# Altium schematic sheet Sensors_and_Comms.SchDoc(Sensors_and_Comms)
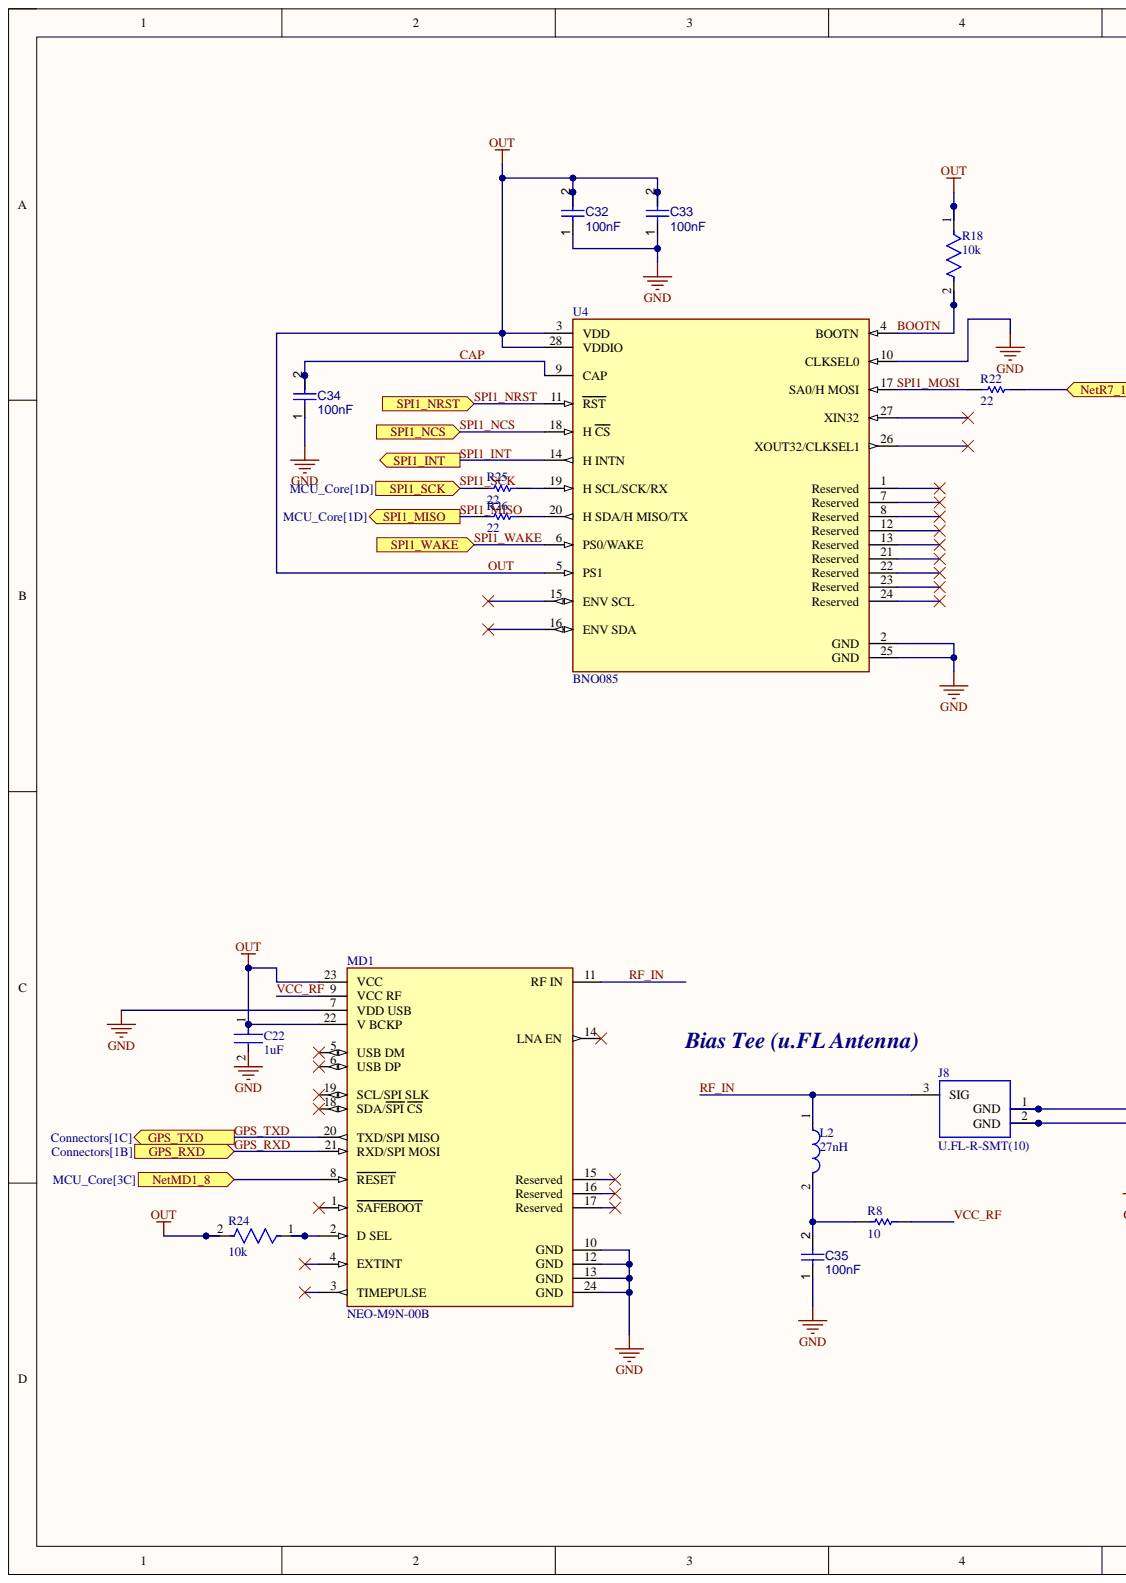
[diagram p0: Sensors_and_Comms.SchDoc, left]
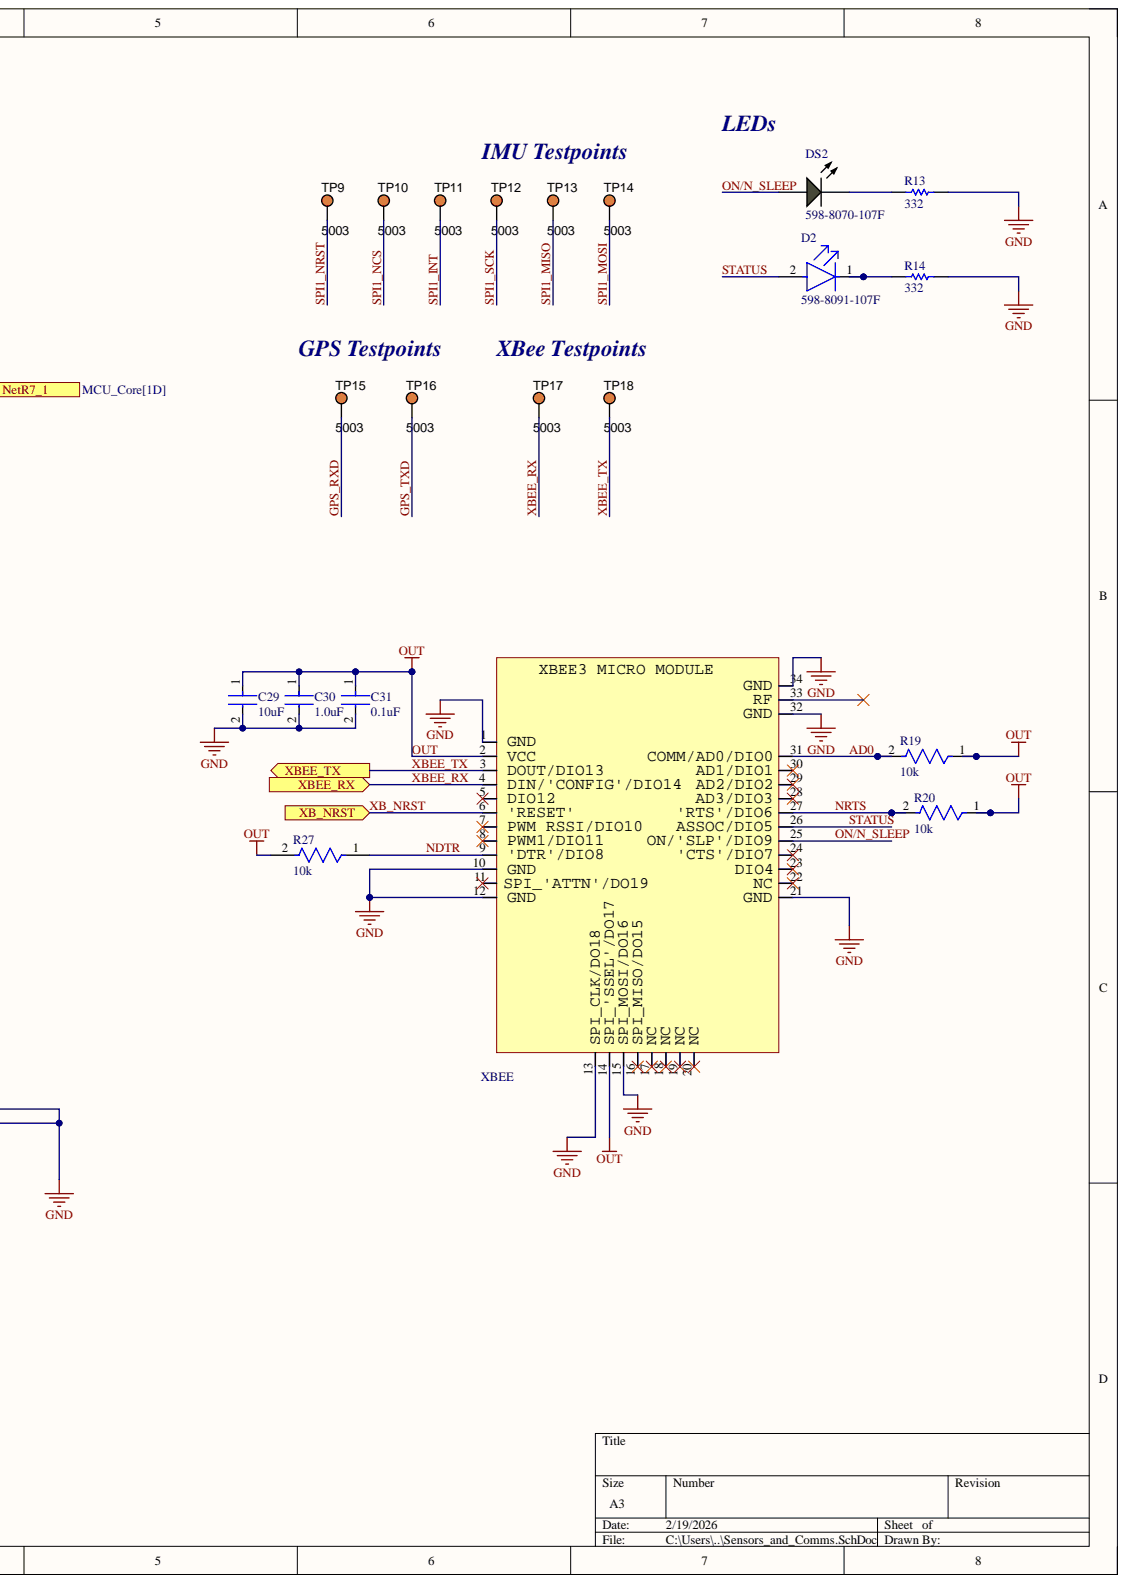
[diagram p1: Sensors_and_Comms.SchDoc, right]

## Parts
C22  1uF  [FP-C0402C105K8PACTU-MFG]
C29  10uF  [FP-CC0805-0_2-1_45-IPC_A]
C30  1.0uF  [FP-CC0603-DA-MFG]
C31  0.1uF  [FP-CC0603-DA-MFG]
C32  100nF  [CAPC1608X90X40NL10T20]
C33  100nF  [CAPC1608X90X40NL10T20]
C34  100nF  [CAPC1608X90X40NL10T20]
C35  100nF  [CAPC1608X90X40NL10T20]
D2  598-8091-107F  [FP-598-8091-107F-MFG]
DS2  598-8070-107F  [DIAL-0603-2_V]
J8  U.FL-R-SMT(10)  [HRS-U.FL-R-SMT_V]
L2  27nH  [FP-LQW18AN_00-MFG]
MD1  NEO-M9N-00B  [FP-NEO-M9N-00B-MFG]
R8  10  [RESC1608X55X25NL10T15]
R13  332  [RESC1608X55X25LL10T15]
R14  332  [RESC1608X55X25LL10T15]
R18  10k  [FP-RC0603-0_55-IPC_C]
R19  10k  [FP-RC0603-0_55-IPC_C]
R20  10k  [FP-RC0603-0_55-IPC_C]
R22  22  [RESC1005X40X25NL05T10]
R24  10k  [FP-RC0603-0_55-IPC_C]
R25  22  [RESC1005X40X25NL05T10]
R26  22  [RESC1005X40X25NL05T10]
R27  10k  [FP-RC0603-0_55-IPC_C]
TP9  5003  [KSTN-5003]
TP10  5003  [KSTN-5003]
TP11  5003  [KSTN-5003]
TP12  5003  [KSTN-5003]
TP13  5003  [KSTN-5003]
TP14  5003  [KSTN-5003]
TP15  5003  [KSTN-5003]
TP16  5003  [KSTN-5003]
TP17  5003  [KSTN-5003]
TP18  5003  [KSTN-5003]
U4  BNO085  [FP-BNO085-MFG]
XBEE  XBee3_SMT MODULE_Micro  [PCBComponent_1]  (value not drawn)

## Ports
GPS_NSRT, GPS_RXD, GPS_TXD, SPI1_INT, SPI1_MISO, SPI1_MOSI, SPI1_NCS, SPI1_NRST, SPI1_SCK, SPI1_WAKE, XB_NRST, XBEE_RX, XBEE_TX

## Nets
GND: C22.2 C29.2 C30.2 C31.2 C32.1 C33.1 C34.1 C35.1 J8.1 J8.2 MD1.7(VDD_USB) MD1.10(GND) MD1.12(GND) MD1.13(GND) MD1.24(GND) R13.2 R14.2 U4.2(GND) U4.10(CLKSEL0) U4.25(GND) XBEE.1(GND) XBEE.10(GND) XBEE.12(GND) XBEE.13(SPI_CLK/DO18) XBEE.15(SPI_MOSI/DO16) XBEE.21(GND) XBEE.32(GND) XBEE.34(GND)
AD0: R19.2 XBEE.31(COMM/AD0/DIO0)
BOOTN: R18.2 U4.4(BOOTN)
CAP: C34.2 U4.9(CAP)
GPS_RXD: MD1.21(RXD/SPI_MOSI) TP15.1 ; port GPS_RXD
GPS_TXD: MD1.20(TXD/SPI_MISO) TP16.1 ; port GPS_TXD
NDTR: R27.1 XBEE.9('DTR'/DIO8)
NRTS: R20.2 XBEE.27('RTS'/DIO6)
ON/N_SLEEP: DS2.2 XBEE.25(ON/'SLP'/DIO9)
PS1: C22.1 C29.1 C30.1 C31.1 C32.2 C33.2 MD1.22(V_BCKP) MD1.23(VCC) R18.1 R19.1 R20.1 R24.2 R27.2 U4.3(VDD) U4.5(PS1) U4.28(VDDIO) XBEE.2(VCC) XBEE.14(SPI_'SSEL'/DO17)
RF_IN: J8.3 L2.1 MD1.11(RF_IN)
SPI1_INT: TP11.1 U4.14(H_INTN) ; port SPI1_INT
SPI1_MISO: R26.1 TP13.1 ; port SPI1_MISO
SPI1_MOSI: R22.1 TP14.1 U4.17(SA0/H_MOSI)
SPI1_NCS: TP10.1 U4.18(H_CS) ; port SPI1_NCS
SPI1_NRST: TP9.1 U4.11(RST) ; port SPI1_NRST
SPI1_SCK: R25.1 TP12.1 ; port SPI1_SCK
SPI1_WAKE: U4.6(PS0/WAKE) ; port SPI1_WAKE
STATUS: D2.2 XBEE.26(ASSOC/DIO5)
VCC_RF: MD1.9(VCC_RF) R8.2
XB_NRST: XBEE.6('RESET') ; port XB_NRST
XBEE_RX: TP17.1 XBEE.4(DIN/'CONFIG'/DIO14) ; port XBEE_RX
XBEE_TX: TP18.1 XBEE.3(DOUT/DIO13) ; port XBEE_TX
NetC35_2: C35.2 L2.2 R8.1
NetD2_1: D2.1 R14.1
NetDS2_1: DS2.1 R13.1
NetMD1_2: MD1.2(D_SEL) R24.1
NetMD1_8: MD1.8(RESET) ; port GPS_NSRT
NetR22_2: R22.2 ; port SPI1_MOSI
NetR25_2: R25.2 U4.19(H_SCL/SCK/RX)
NetR26_2: R26.2 U4.20(H_SDA/H_MISO/TX)

Not connected: MD1 1(SAFEBOOT) 3(TIMEPULSE) 4(EXTINT) 5(USB_DM) 6(USB_DP) 14(LNA_EN) 15(Reserved) 16(Reserved) 17(Reserved) 18(SDA/SPI_CS) 19(SCL/SPI_SLK); U4 1(Reserved) 7(Reserved) 8(Reserved) 12(Reserved) 13(Reserved) 15(ENV_SCL) 16(ENV_SDA) 21(Reserved) 22(Reserved) 23(Reserved) 24(Reserved) 26(XOUT32/CLKSEL1) 27(XIN32); XBEE 5(DIO12) 7(PWM) 8(PWM1/DIO11) 11(SPI_'ATTN'/DO19) 16(SPI_MISO/DO15) 17(NC) 18(NC) 19(NC) 20(NC) 22(NC) 23(DIO4) 24('CTS'/DIO7) 28(AD3/DIO3) 29(AD2/DIO2) 30(AD1/DIO1) 33(RF)

## Library data of the parts
C22 = CMP-2008-02081-2: CAP CER 1UF 10V X5R 0402; Dielectric Material: Ceramic; Dissipation Factor: 5%; Tolerance: 10%; Capacitance: 1uF; Case Code (Imperial): 0402; Case Code (Metric): 1005; Case/Package: 0402; Component Kind: Standard; Construction: Flat; Contact Plating: Tin; Depth: 0.5mm; Dielectric: X5R; Height: 0.5mm; Lead Free: Lead Free; Length: 1mm; Library Name: Altium Content Vault; Max Operating Temperature: 85°C; Min Operating Temperature: -55°C; Mount: Surface Mount; Number of Pins: 2; Package Quantity: 1; Packaging: Tape and Reel; Radiation Hardening: No; REACH SVHC: No SVHC; RoHS Compliant: Yes; Series: C; Termination: SMD/SMT; Thickness: 0.022inch; Voltage: 10V; Voltage Rating: 10V; Voltage Rating (DC): 10V; Width: 0.5mm
C29 = CMP-03422-001501-1: CAP CER 10UF 25V X5R 0805; Tolerance: 10%; Capacitance: 10uF; Case Code (Imperial): 0805; Case Code (Metric): 2012; Case/Package: 0805; Component Kind: Standard; Depth: 1.25mm; Dielectric: X5R; Halogen Free: Halogen Free; Lead Free: Lead Free; Length: 0.079inch; Library Name: Altium Content Vault; Max Operating Temperature: 85°C; Min Operating Temperature: -55°C; Mount: Surface Mount; Packaging: Tape and Reel; Resistor Type: Ceramic; RoHS Compliant: Yes; Series: CC; Termination: SMD/SMT; Thickness: 0.057inch; Voltage Rating: 25V; Voltage Rating (DC): 25V; Weight: 0.000194oz; Width: 0.049inch
C30 = CMP-03422-000612-1: CAP CER 1UF 25V X5R 0603; Manufacturer: YAGEO; Manufacturer Part Number: CC0603KRX5R8BB105; Material: Ceramic; Temperature Coefficient: 15%; Tolerance: 0.1; Automotive: No; Capacitance: 1 uF; Category: Cap; Component Kind: Standard; ComponentLink2Description: Datasheet; ComponentLink2URL: http://www.yageo.com/documents/recent/UPY-GPHC_X7R_6.3V-to-50V_18.pdf; Device Class L1: Passive Components; Device Class L2: Capacitors; Device Class L3: Ceramic Capacitors; Digikey Description: CAP CER 1UF 25V X5R 0603; Footprint Url: http://www.yageo.com/exep/pages/download/literatures/UPY-C_GEN_24.pdf; Height: 0.9mm; Lead Free: Yes; Library Name: Altium Content Vault; Mouser Description: Multilayer Ceramic Capacitors MLCC - SMD/SMT 1.0uF 25V X5R 10%; Octopart: https://octopart.com/cc0603krx5r8bb105-yageo-9292811; Package: CC0603-DA; RoHS: TRUE; Temperature: -55°C to +125°C; Temperature Characteristic: X5R; Temperature Range High: +125°C; Temperature Range Low: -55°C; Value: 1 uF; Voltage: 25 V; Voltage Rating: 25 V
C31 = CMP-03422-000468-1: CAP CER 0.1UF 50V X7R 0603; Manufacturer: YAGEO; Manufacturer Part Number: CC0603KPX7R9BB104; Capacitance: 100 nF; Material: Ceramic; Temperature Coefficient: 15%; Tolerance: 0.1; Value: 100 nF; Automotive: No; Category: Cap; Component Kind: Standard; ComponentLink2Description: Datasheet; ComponentLink2URL: http://www.yageo.com/documents/recent/UPY-GPHC_X7R_6.3V-to-50V_18.pdf; Device Class L1: Passive Components; Device Class L2: Capacitors; Device Class L3: Ceramic Capacitors; Digikey Description: CAP CER 0.1UF 50V X7R 0603; Footprint Url: http://www.yageo.com/exep/pages/download/literatures/UPY-C_GEN_24.pdf; Height: 0.9mm; Lead Free: Yes; Library Name: Altium Content Vault; Mouser Description: Multilayer Ceramic Capacitors MLCC - SMD/SMT 100nF 50V X7R 10%; Octopart: https://octopart.com/cc0603kpx7r9bb104-yageo-12885113; Package: CC0603-DA; RoHS: TRUE; Temperature: -55°C to +125°C; Temperature Characteristic: X7R; Temperature Range High: +125°C; Temperature Range Low: -55°C; Voltage: 50 V; Voltage Rating: 50 V
C32-C35 = CMP-001-00017-6: Chip Capacitor, 100 nF, +/- 10%, 50 V, 0603 (1608 Metric); Capacitor Type: Ceramic; Voltage Rating: 50V; Case/Package: 0603; Component Kind: Standard; Max Operating Temperature: 125°C; Min Operating Temperature: -55°C; Mounting Technology: SM; Pins: 2; RoHS Compliant: True; Tolerance: 10%; Value: 100nF; Library Name: Thomas Tran
D2 = CMP-15384-000008-1: LED BLUE CLEAR 0603 SMD; Dominant Wavelength: 470nm; Forward Current: 20mA; Forward Voltage: 3.2V; Lens Color: Colorless; Lens Size: 0.8mm; Lens Style: Flat; Luminous Intensity: 140mcd; Number of Elements: 1; Power Dissipation: 70mW; Reverse Voltage: 5V; Viewing Angle: 140°; Wavelength: 475nm; Case Code (Imperial): 0603; Case Code (Metric): 1608; Case/Package: 0603; Color: Blue; Component Kind: Standard; Depth: 0.8mm; Height: 0.7mm; Illumination Color: Blue; Lead Free: Lead Free; Length: 1.6mm; Lens Transparency: Clear; Library Name: Altium Content Vault; Max Operating Temperature: 100°C; Min Operating Temperature: -30°C; Mount: Surface Mount; Number of LEDs: 1; Number of Pins: 2; Package Quantity: 4000; Packaging: Tape and Reel; Radiation Hardening: No; RoHS Compliant: Yes; Series: 598; Test Current: 20mA; Width: 0.8mm
DS2 = CMP-2000-05654-1: Single Color LED, 2 V, -30 to 85 degC, 2-Pin SMD (0603), RoHS, Tape and Reel; Manufacturer: Dialight; Chip Material: GaP; DELETED: Compliant; Dominant Wave Length: 568nm; Emitting Color: YellowGreen; Forward Current: 25mA; Forward Voltage: 2.4V; High Brightness: No; Lum Intensity: 20mcd; Main Category: ChipLED; Number of  Elements: 1; Power Dissipation: 60W; Product Depth (mm): 0.8mm; Product Height (mm): 0.7mm; Product Length (mm): 1.6mm; Reverse Voltage: 5V; Shape Type: Rectangular; Test Current (It): 20mA; Type: Uni-Color; Viewing Angle: 140deg; Component Kind: Standard; Datasheet URL: https://datasheet.ciiva.com/4688/598-selectorguide-18902-4688880.pdf; Datasheet Version: Rev. 2, 10/2008; Lens Dimensions: 1.1x0.8x0.4mm; Library Name: Altium Content Vault; Luminous Intensity: 20mcd; Manufacturer URL: http://www.dialight.com/; Mounting: SurfaceMount; Mounting Technology: SurfaceMount; Operating Temp Range: -40Cto100C; Operating Temperature Classification: Industrial; Package Description: 2-Pin Surface Mount Device, Body 1.6 x 0.8 mm; Package Reference: 0603; Package Type: SMD; Packaging: TapeandReel; Pin Count: 2; Rad Hardened: No
J8 = CMP-002-00035-2: Ultra Small Surface Mount Coaxial Connector, 50 Ohm, -40 to 90 degC, 3-Pin SMD, RoHS, Tape and Reel; Manufacturer: Hirose Electric; Connector Type: RF&Coax; Impedance: 50Ohm; Case/Package: U.FL-R-SMT; Component Kind: Standard; Contact Material: Brass; Contact Plating: Gold; Datasheet URL: https://www.hirose.com/product/en/download_file/key_name/U.FL/category/Catalog/doc_file_id/31697/?file_category_id=4&item_id=15&is_series=1; Datasheet Version: Aug-16; Fastening Type: Snap; Manufacturer URL: https://www.hirose.com; Max Operating Temperature: 90°C; Min Operating Temperature: -40°C; Mounting Technology: SM; Package Description: 3-Pin Surface Mount Device, Body 2.6 x 2.6 mm; Package Quantity: 2500; Package Reference: U.FL-R-SMT; Packaging: TapeandReel; Resistance: 50Ohm; RoHS Compliant: True; Library Name: Thomas Tran
L2 = CMP-06042-006496-1: Wire Wound RF Inductor 27nH ±2% 440mA 0.21Ω 0603 (1608); Core Material: Non-Magnetic; Current: 850mA; DC Resistance (DCR): 210mR; Q Factor: 40; Self Resonant Frequency: 3.7GHz; Shielding: Unshielded; Test Frequency: 100MHz; Case Code (Imperial): 0603; Case Code (Metric): 1608; Case/Package: 0603; Component Kind: Standard; Current Rating: 440mA; DC Current: 440mA; Depth: 1mm; Height: 0.8mm; Height - Seated (Max): 0.039inch; Inductance: 27nH; Lead Free: Lead Free; Length: 1.6mm; Library Name: Altium Content Vault; Max DC Current: 440mA; Max Operating Temperature: 125°C; Military Standard: Not; Min Operating Temperature: -55°C; Mount: Surface Mount; Package Quantity: 4000; Packaging: Tape and Reel; Radiation Hardening: No; Resistor Type: Wirewound; Series Resistance: 210mR; Termination: SMD/SMT; Tolerance: 2%; Weight: 0.000106oz; Width: 0.031inch
MD1 = CMP-207130-000006-2: M9 standard precision module; Manufacturer: u-blox; Interface: I2C,SPI,UART,USB; Max Supply Voltage: 3.6V; Min Supply Voltage: 1.65V; Sensitivity: -167dBm; Automotive: No; Category: UNK; Component Kind: Standard; ComponentLink2Description: Datasheet; ComponentLink2URL: https://www.u-blox.com/sites/default/files/NEO-M9N_DataSheet_%28UBX-19014285%29.pdf; Device Class L1: Integrated Circuits (ICs); Device Class L2: RF Semiconductors and Devices; Device Class L3: RF Receivers; Footprint Url: https://www.u-blox.com/sites/default/files/NEO-M9N_Integrationmanual_%28UBX-19014286%29.pdf; Height: 2.6mm; Lead Free: Yes; Library Name: Altium Content Vault; Manufacturer Part Number: NEO-M9N-00B; Octopart: https://octopart.com/neo-m9n-00b-u-blox-107315722; Package: 0; RoHS: TRUE; Temperature: -40°C to +85°C; Temperature Range High: +85°C; Temperature Range Low: -40°C
R8 = CMP-1659-00008-4: Chip Resistor, 10 Ohm, +/- 1%, 0.1 W, -55 to 155 degC, 0603 (1608 Metric), RoHS, Tape and Reel; Manufacturer: Yageo; Voltage Rating: 50 V; Component Kind: Standard; ComponentLink1Description: Manufacturer URL; ComponentLink1URL: http://www.yageo.com/; ComponentLink2Description: Datasheet; ComponentLink2URL: http://www.yageo.com/documents/recent/PYu-RC_Group_51_RoHS_L_04.pdf; ComponentLink3Description: Package Specification; ComponentLink3URL: http://www.farnell.com/datasheets/443971.pdf; DatasheetVersion: Rev. 4, 02/2016; Height: 0.45 mm; Length: 1.6 mm; Library Name: Altium Content Vault; Max Operating Temperature: 155 degC; Min Operating Temperature: -55 degC; Mount: Surface Mount; Mounting Technology: Surface Mount; Package Quantity: 200; PackageDescription: 2-Pin Surface Mount Device, Body 1.6 x 0.8 mm; PackageReference: 0603; Packaging: Tape and Reel; Pins: 2; REACH SVHC: No SVHC; RoHSCompliant: true
R13 R14 = CMP-2000-04013-1: Manufacturer: Yageo; Part Number: RC0603FR-07332RL; Component Kind: Standard; Library Name: Altium Content Vault
R18-R20 R24 R27 = CMP-2100-03664-2: RES 10K OHM 1% 1/10W 0603; Max Power Dissipation: 100mW; Resistance: 10kR; Temperature Coefficient: 100ppm/°C; Voltage Rating: 75V; Voltage Rating (DC): 50V; Case Code (Imperial): 0603; Case Code (Metric): 1608; Case/Package: 0603; Component Kind: Standard; Construction: Rectangular; Depth: 0.8mm; Features: Moisture Resistant; Height: 0.45mm; Lead Free: Lead Free; Lead Pitch: 0.3mm; Length: 1.6mm; Library Name: Altium Content Vault; Max Operating Temperature: 155°C; Military Standard: Not; Min Operating Temperature: -55°C; Number of Pins: 2; Number of Terminations: 2; Packaging: Tape and Reel; Power Rating: 100mW; Resistance Tolerance: 1%; Resistor Type: Thick Film; RoHS Compliant: Yes; Series: RC; Size Code: 0603; Termination: SMD/SMT; Tolerance: 1%; Weight: 7.1E-05oz; Width: 0.8mm
R22 R25 R26 = CMP-2002-07519-1: Manufacturer: Yageo; Part Number: RC0402FR-0722R6L; Component Kind: Standard; Library Name: Altium Content Vault
TP9-TP18 = CMP-002-00037-2: Test Point, Orange, Height 4.6 mm, Tail Length 3 mm, 1-Pin THD, RoHS; Manufacturer: Keystone; Case/Package: 5003; Colour: Orange; Component Kind: Standard; Connector Type: TestPoint; Contact Material: Bronze; Contact Plating: Tin; Datasheet URL: http://keyelco.com/userAssets/file/M65p56.pdf; Datasheet Version: Jun-15; Diameter: 2.54mm; Manufacturer URL: http://www.keyelco.com/; Mounting Technology: TH; Package Description: 1-Pin Through Hole Device, Dia 2.54 mm; Package Quantity: 10; Package Reference: 5003; Packaging: Bulk; Pins: 1; RoHS Compliant: True; Library Name: Thomas Tran
U4 = CMP-197298-000001-1: IMU ACCEL/GYRO/MAG I2C 32BIT; Manufacturer: Hillcrest Laboratories, Inc.; Accel Range: 8g; Max Supply Voltage: 3.6V; Min Supply Voltage: 1.7V; Sensor Output: I2C,SPI,UART; Sensor Type: Accelerometer,Gyroscope,Magnetometer; Automotive: No; Category: IC; Component Kind: Standard; ComponentLink2Description: Datasheet; ComponentLink2URL: https://www.hillcrestlabs.com/download/5a747b8d566d073a5d000536; Device Class L1: Sensors; Device Class L2: Motion Sensors; Device Class L3: Inertial Measurement Units (IMUs); Digikey Description: IMU ACCEL/GYRO/MAG I2C 32BIT; Height: 1.18mm; Lead Free: Yes; Library Name: Altium Content Vault; Manufacturer Part Number: BNO085; Octopart: https://octopart.com/bno085-hillcrest+laboratories-92813482; Package: BNO085; RoHS: TRUE; Temperature: -40°C to +85°C; Temperature Range High: +85°C; Temperature Range Low: -40°C
XBEE = XBee3_SMT MODULE_Micro: Component Kind: Standard; Description: XBee3_SMT MODULE_Micro; Library Name: Xbee3.IntLib
